# Altium schematic sheet Sheet.SchDoc
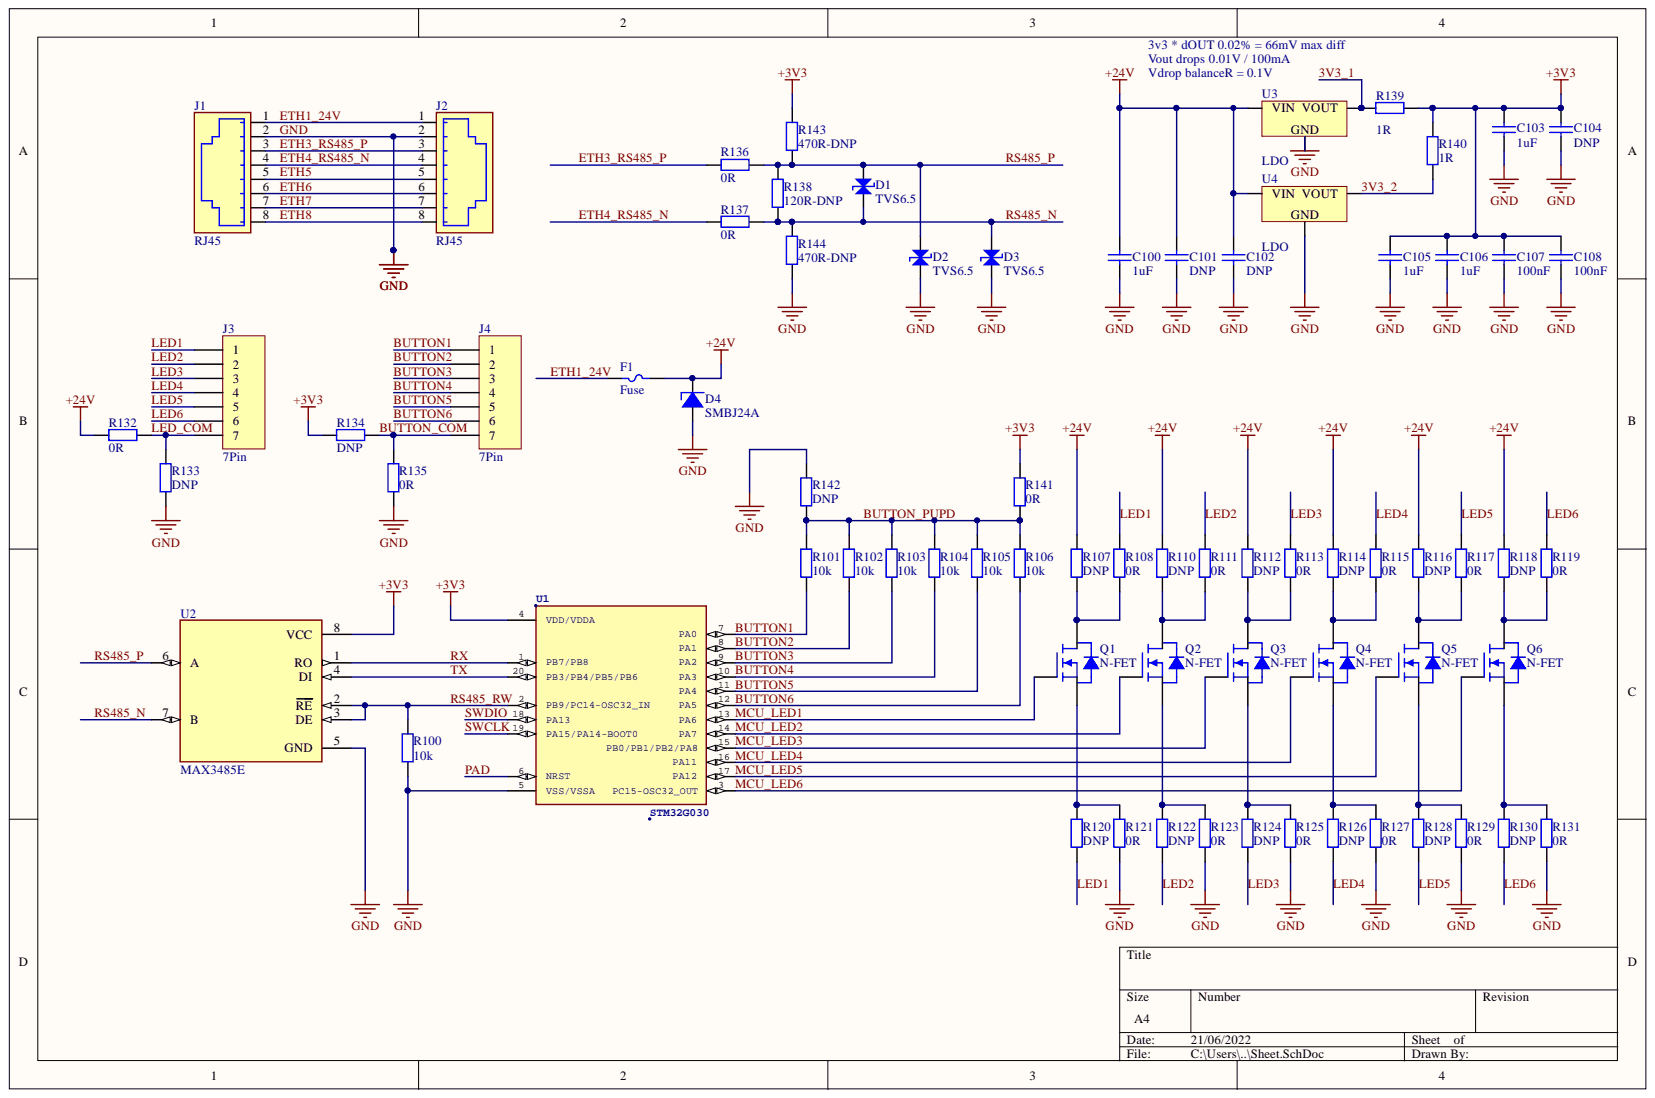
[diagram p0: Sheet.SchDoc]

## Parts
C100  1uF  [C0603]
C101  DNP  [C0603]
C102  DNP  [C0603]
C103  1uF  [C0603]
C104  DNP  [C0603]
C105  1uF  [C0603]
C106  1uF  [C0603]
C107  100nF  [C0603]
C108  100nF  [C0603]
D1  TVS6.5  [SOD323]
D2  TVS6.5  [SOD323]
D3  TVS6.5  [SOD323]
D4  SMBJ24A  [SMB]
F1  Fuse  [PPTC1812]
J1  RJ45  [RJ45_RA]
J2  RJ45  [RJ45_RA]
J3  7Pin  [7Pin]
J4  7Pin  [7Pin]
Q1  N-FET  [SOT323]
Q2  N-FET  [SOT323]
Q3  N-FET  [SOT323]
Q4  N-FET  [SOT323]
Q5  N-FET  [SOT323]
Q6  N-FET  [SOT323]
R100  10k  [R0603]
R101  10k  [R0603]
R102  10k  [R0603]
R103  10k  [R0603]
R104  10k  [R0603]
R105  10k  [R0603]
R106  10k  [R0603]
R107  DNP  [R0603]
R108  0R  [R0603]
R110  DNP  [R0603]
R111  0R  [R0603]
R112  DNP  [R0603]
R113  0R  [R0603]
R114  DNP  [R0603]
R115  0R  [R0603]
R116  DNP  [R0603]
R117  0R  [R0603]
R118  DNP  [R0603]
R119  0R  [R0603]
R120  DNP  [R0603]
R121  0R  [R0603]
R122  DNP  [R0603]
R123  0R  [R0603]
R124  DNP  [R0603]
R125  0R  [R0603]
R126  DNP  [R0603]
R127  0R  [R0603]
R128  DNP  [R0603]
R129  0R  [R0603]
R130  DNP  [R0603]
R131  0R  [R0603]
R132  0R  [R0603]
R133  DNP  [R0603]
R134  DNP  [R0603]
R135  0R  [R0603]
R136  0R  [R0603]
R137  0R  [R0603]
R138  120R-DNP  [R0603]
R139  1R  [R0603]
R140  1R  [R0603]
R141  0R  [R0603]
R142  DNP  [R0603]
R143  470R-DNP  [R0603]
R144  470R-DNP  [R0603]
U1  STM32G030  [TSSOP20]
U2  MAX3485E  [SOIC-08]
U3  LDO  [SOT-23-5]
U4  LDO  [SOT-23-5]

## Nets
+3V3: C103.2 C104.2 C105.2 C106.2 C107.2 C108.2 R134.2 R139.1 R140.2 R141.2 R143.2 U1.4(VDD/VDDA) U2.8(VCC)
+24V: C100.2 C101.2 C102.2 D4.1 F1.2 R107.2 R110.2 R112.2 R114.2 R116.2 R118.2 R132.2 U3.1 U4.1
3V3_1: R139.2 U3.5
3V3_2: R140.1 U4.5
BUTTON1: J4.1 R101.1 U1.7(PA0)
BUTTON2: J4.2 R102.1 U1.8(PA1)
BUTTON3: J4.3 R103.1 U1.9(PA2)
BUTTON4: J4.4 R104.1 U1.10(PA3)
BUTTON5: J4.5 R105.1 U1.11(PA4)
BUTTON6: J4.6 R106.1 U1.12(PA5)
BUTTON_COM: J4.7 R134.1 R135.1
BUTTON_PUPD: R101.2 R102.2 R103.2 R104.2 R105.2 R106.2 R141.1 R142.1
ETH1_24V: F1.1 J1.1 J2.1
ETH3_RS485_P: J1.3 J2.3 R136.1
ETH4_RS485_N: J1.4 J2.4 R137.1
ETH5: J1.5 J2.5
ETH6: J1.6 J2.6
ETH7: J1.7 J2.7
ETH8: J1.8 J2.8
GND: C100.1 C101.1 C102.1 C103.1 C104.1 C105.1 C106.1 C107.1 C108.1 D2.1 D3.1 D4.2 J1.2 J2.2 R100.1 R121.1 R123.1 R125.1 R127.1 R129.1 R131.1 R133.2 R135.2 R142.2 R144.1 U1.5(VSS/VSSA) U2.5(GND) U3.2 U4.2
LED1: J3.1 R108.2 R120.1
LED2: J3.2 R111.2 R122.1
LED3: J3.3 R113.2 R124.1
LED4: J3.4 R115.2 R126.1
LED5: J3.5 R117.2 R128.1
LED6: J3.6 R119.2 R130.1
LED_COM: J3.7 R132.1 R133.1
MCU_LED1: Q1.1 U1.13(PA6)
MCU_LED2: Q2.1 U1.14(PA7)
MCU_LED3: Q3.1 U1.15(PB0/PB1/PB2/PA8)
MCU_LED4: Q4.1 U1.16(PA11)
MCU_LED5: Q5.1 U1.17(PA12)
MCU_LED6: Q6.1 U1.3(PC15-OSC32_OUT)
PAD: U1.6(NRST)
RS485_N: D1.1 D3.2 R137.2 R138.1 R144.2 U2.7(B)
RS485_P: D1.2 D2.2 R136.2 R138.2 R143.1 U2.6(A)
RS485_RW: R100.2 U1.2(PB9/PC14-OSC32_IN) U2.2(RE) U2.3(DE)
RX: U1.1(PB7/PB8) U2.1(RO)
SWCLK: U1.19(PA15/PA14-BOOT0)
SWDIO: U1.18(PA13)
TX: U1.20(PB3/PB4/PB5/PB6) U2.4(DI)
NetQ1_2: Q1.2 R120.2 R121.2
NetQ1_3: Q1.3 R107.1 R108.1
NetQ2_2: Q2.2 R122.2 R123.2
NetQ2_3: Q2.3 R110.1 R111.1
NetQ3_2: Q3.2 R124.2 R125.2
NetQ3_3: Q3.3 R112.1 R113.1
NetQ4_2: Q4.2 R126.2 R127.2
NetQ4_3: Q4.3 R114.1 R115.1
NetQ5_2: Q5.2 R128.2 R129.2
NetQ5_3: Q5.3 R116.1 R117.1
NetQ6_2: Q6.2 R130.2 R131.2
NetQ6_3: Q6.3 R118.1 R119.1

## Library data of the parts
C100-C108 = Capacitor: Component Kind: Standard; Library Name: Library.IntLib
D1-D3 = TVS Bi: Component Kind: Standard; Library Name: Library.IntLib
D4 = TVS Uni: Component Kind: Standard; Library Name: Library.IntLib
J1 J2 = RJ45: Jack Modular Connector 8p8c (RJ45, Ethernet) Vertical Unshielded Cat5; MF: Wurth Elektronik; MP: 615008144221; Availability: In Stock; Component Kind: Standard; Library Name: Library.IntLib; Package: None; Price: None; Purchase-URL: https://pricing.snapeda.com/search/part/615008144221/?ref=eda
R100-R108 R110-R144 = Resistor: Component Kind: Standard; Library Name: Library.IntLib
U1 = STM32G030: Mfr_Name: STMicroelectronics; DeviceName: TSSOP20-L; DeviceSetName: STM32G030F6P6TR; GateName_1: A; Component Kind: Standard; Library Name: Schlib1.SchLib; LibraryName: 2022-06-19_13-31-56_Library; Manufacturer_Part_Number: STM32G030F6P6TR; SymbolName_1: STM32G030F6P6TR; Copyright: Copyright (C) 2022 Ultra Librarian. All rights reserved.
U2 = MAX3485E: 1/1 Transceiver Half RS422, RS485 8-SOIC; MF: Maxim Integrated; MP: MAX3485EESA+T; Check_prices: https://www.snapeda.com/parts/MAX3485EESA+T/Maxim%20Integrated/view-part/574654/?ref=eda; Component Kind: Standard; Digi-Key_Part_Number: MAX3485EESA+TCT-ND; Library Name: Library.IntLib; Package: SOIC-8 Maxim; SnapEDA_Link: https://www.snapeda.com/parts/MAX3485EESA+T/Maxim%20Integrated/view-part/574654/?ref=snap
U3 U4 = LDO: MF: Texas Instruments; MP: LP38690DT-5.0/NOPB; Availability: Warning; Component Kind: Standard; Library Name: Schlib1.SchLib; Package: TO-252-3 Texas Instruments; Price: None; Purchase-URL: https://pricing.snapeda.com/search/part/LP38690DT-5.0/NOPB/?ref=eda; SnapEDA_Link: https://www.snapeda.com/parts/LP38690DT-5.0/NOPB/Texas%20Instruments/view-part/25360/?ref=snap
F1 = Fuse: Component Kind: Standard; Library Name: Library.IntLib
J3 J4 = 7Pin: Component Kind: Standard; Library Name: Library.IntLib
Q1-Q6 = N-FET: Component Kind: Standard; Library Name: Library.IntLib
